# Altium schematic sheet Relays.SchDoc
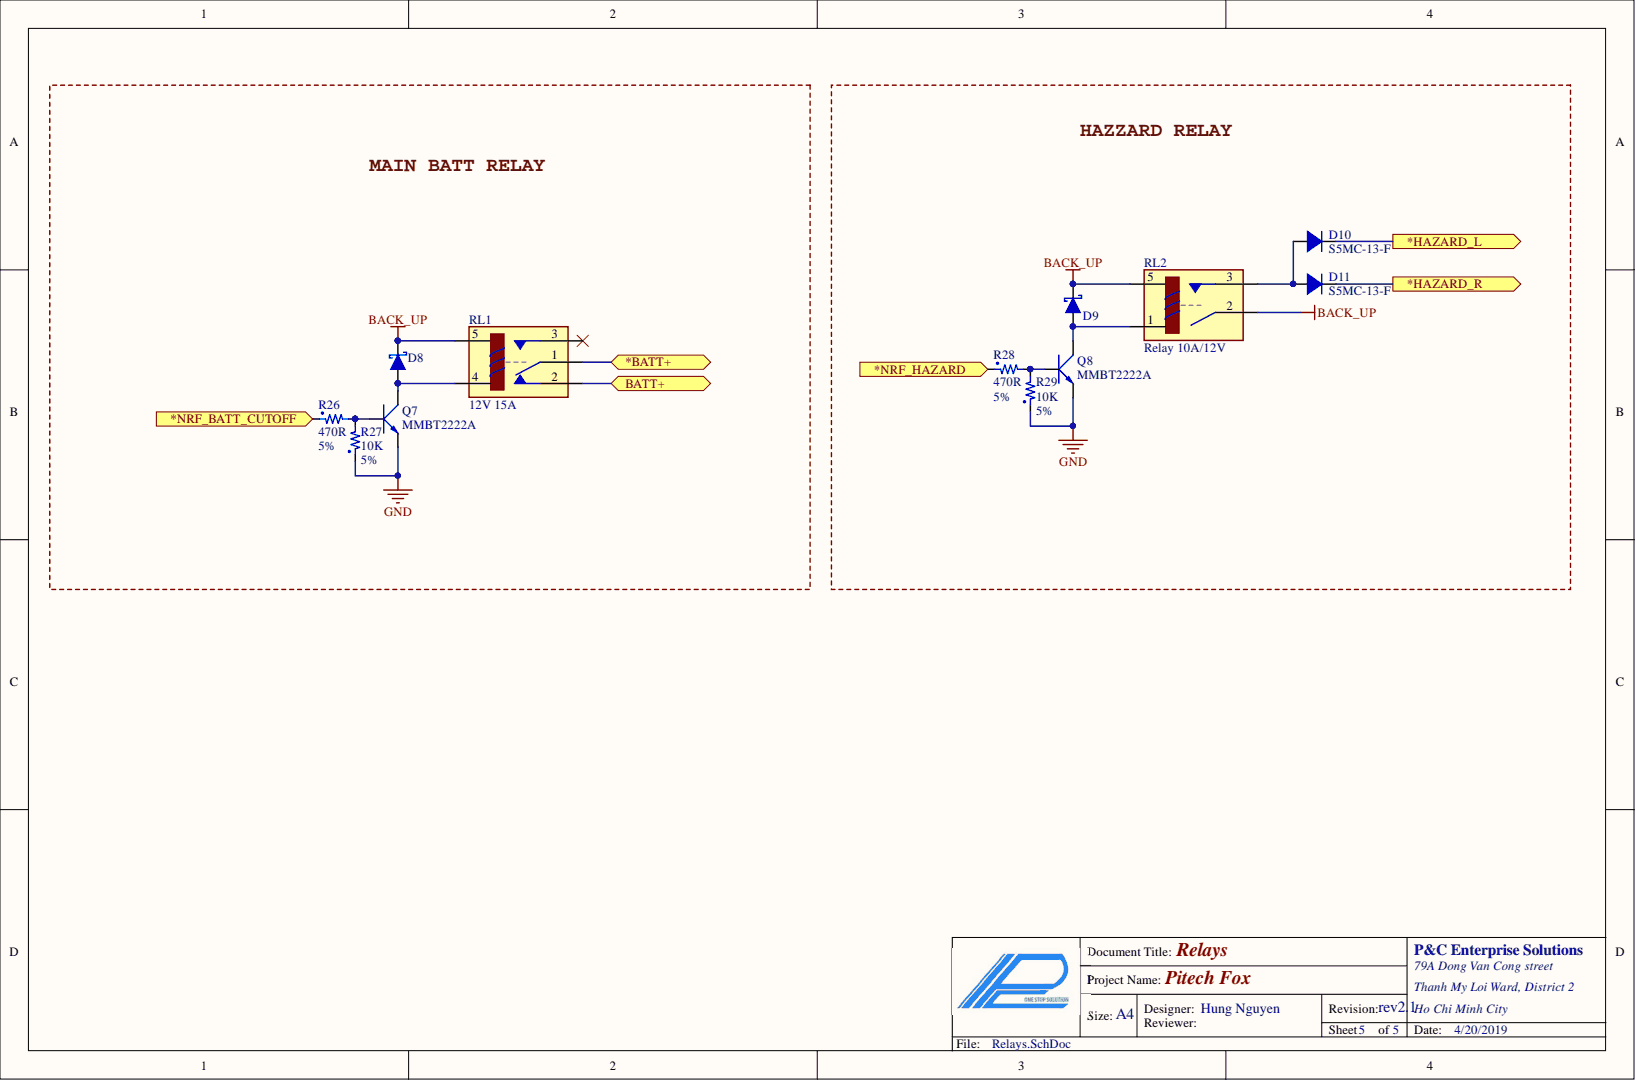
[diagram p0: Relays.SchDoc]

## Parts
D8  SS14-M3/5AT  [DO-214AC]  (value not drawn)
D9  SS14-M3/5AT  [DO-214AC]  (value not drawn)
D10  S5MC-13-F  [DO214AB (SMC)]
D11  S5MC-13-F  [DO214AB (SMC)]
Q7  MMBT2222A  [SOT23]
Q8  MMBT2222A  [SOT23]
R26  470R  [R0603 (1608 Metric)]
R27  10K  Tolerance: 5%  [R0603 (1608 Metric)]
R28  470R  Tolerance: 5%  [R0603 (1608 Metric)]
R29  10K  Tolerance: 5%  [R0603 (1608 Metric)]
RL1  12V 15A  Contact Current Rating: 15A  [Relay_JS1_Panasonic]
RL2  Relay 10A/12V  [G5Q-1A]

## Ports
*BATT+, *HAZARD_L, *HAZARD_R, *NRF_BATT_CUTOFF, *NRF_HAZARD, BATT+

## Nets
BACK_UP: D8.2 D9.2 RL1.5 RL2.2 RL2.5
GND: Q7.2 Q8.2 R27.1 R29.1
*BATT+: RL1.1 ; port *BATT+
*HAZARD_L: D10.2 ; port *HAZARD_L
*HAZARD_R: D11.2 ; port *HAZARD_R
*NRF_BATT_CUTOFF: R26.1 ; port *NRF_BATT_CUTOFF
*NRF_HAZARD: R28.1 ; port *NRF_HAZARD
BATT+: RL1.2 ; port BATT+
NetD8_1: D8.1 Q7.3 RL1.4
NetD9_1: D9.1 Q8.3 RL2.1
NetD10_1: D10.1 D11.1 RL2.3
NetQ7_1: Q7.1 R26.2 R27.2
NetQ8_1: Q8.1 R28.2 R29.2

Not connected: RL1 3

## Library data of the parts
D8 D9 = SS14-M3/5AT: Schottky Diodes & Rectifiers 1A,40V,SM SCHOTTKY RECT; Mfr: Vishay Semiconductors; Component Kind: Standard; ComponentLink1Description: Manufacturer Link; ComponentLink1URL: http://www.irf.com/; ComponentLink2Description: Datasheet; ComponentLink2URL: http://www.irf.com/product-info/datasheets/data/1n6096.pdf; If - Forward Current: 1A; Library Name: Diode.SchLib; Mfr Part Number: SS14-M3/5AT; Supplier 1: Mouser; Supplier Part Number 1: 78-SS14-M35AT; Vrrm - Repetitive Reverse Voltage: 40V
D10 D11 = S5MC-13-F: Rectifiers 1000V 5A; Mfr: Diodes Incorporated; Component Kind: Standard; Forward Current: 5A; Library Name: Diode.SchLib; Mfr Part Number: S5MC-13-F; Package: SMC; Reverse Voltage: 1000V; Supplier 1: Mouser; Supplier Part Number 1: 621-S5MC-F
Q7 Q8 = MMBT2222AWT1G: Bipolar Transistors - BJT SS SOT23 GP XSTR NPN 40V; Mfr: ON Semiconductor; Component Kind: Standard; Library Name: BJT.SchLib; Mfr Part Number: MMBT2222AWT1G; Supplier 1: Mouser; Supplier Part Number 1: 863-MMBT2222AWT1G
R26 R28 = RC0603JR-07470RL: Thick Film Resistors - SMD 470 OHM 5% Case 0603; Mfr: Yageo; Case: 0603 (1608 metric); Component Kind: Standard; Library Name: ChipResistor.SchLib; Mfr Part Number: RC0603JR-07470RL; Supplier 1: Mouser; Supplier Part Number 1: 603-RC0603JR-07470RL; Value: 470R
  R26: Tolerance: 5%
R27 R29 = RC0603JR-0710KL: Thick Film Resistors - SMD 10K OHM 5% Case 0603; Mfr: Yageo; Case: 0603 (1608 metric); Component Kind: Standard; Library Name: ChipResistor.SchLib; Mfr Part Number: RC0603JR-0710KL; Supplier 1: Mouser; Supplier Part Number 1: 603-RC0603JR-0710KL; Value: 10K
RL1 = JSM1-12V-5: Automotive Relays 15A 12VDC SPDT HI-CAP SEALED PCB; Mfr: Panasonic Industrial Devices; Relay Contact Form: 1 Form C (SPDT-NO, NC); Coil Voltage: 12VDC; Component Kind: Standard; Library Name: Relays.SchLib; Mfr Part Number: JSM1-12V-5; Size: 22.0 x 16.0 x 16.0mm; Supplier 1: Mouser; Supplier Part Number 1: 769-JSM1-12V-5
RL2 = G5Q-1A-EL-HA-VH DC12: Relay SPDT,10A - 12VDC 5 Pin; Mfr: Panasonic Industrial Devices; Relay Contact Form: 1 Form A (SPST-NO); Coil Voltage: 12VDC; Component Kind: Standard; Contact Current Rating: 10A; Library Name: Relays.SchLib; Mfr Part Number: G5Q-1A-EL-HA-VH DC12; Size: 10.3 x 20.3 x 15.8mm; Supplier 1: Mouser; Supplier Part Number 1: 653-G5Q1AELHAVHDC12
